# Altium schematic sheet 20-FPGA_2.SchDoc(20-FPGA_2)
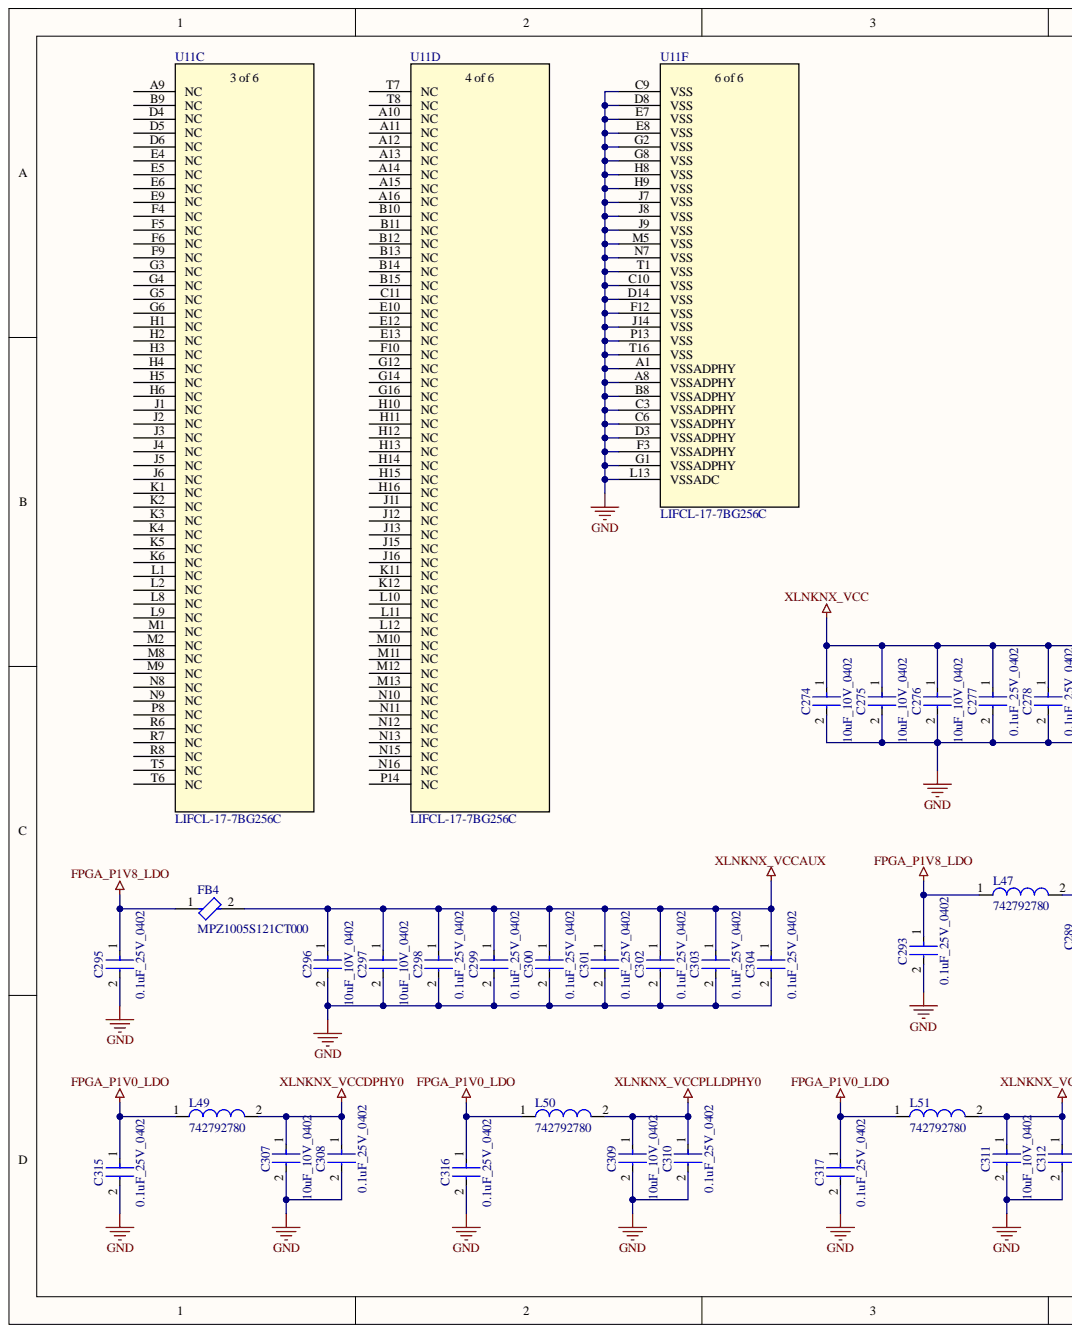
[diagram p0: 20-FPGA_2.SchDoc, left]
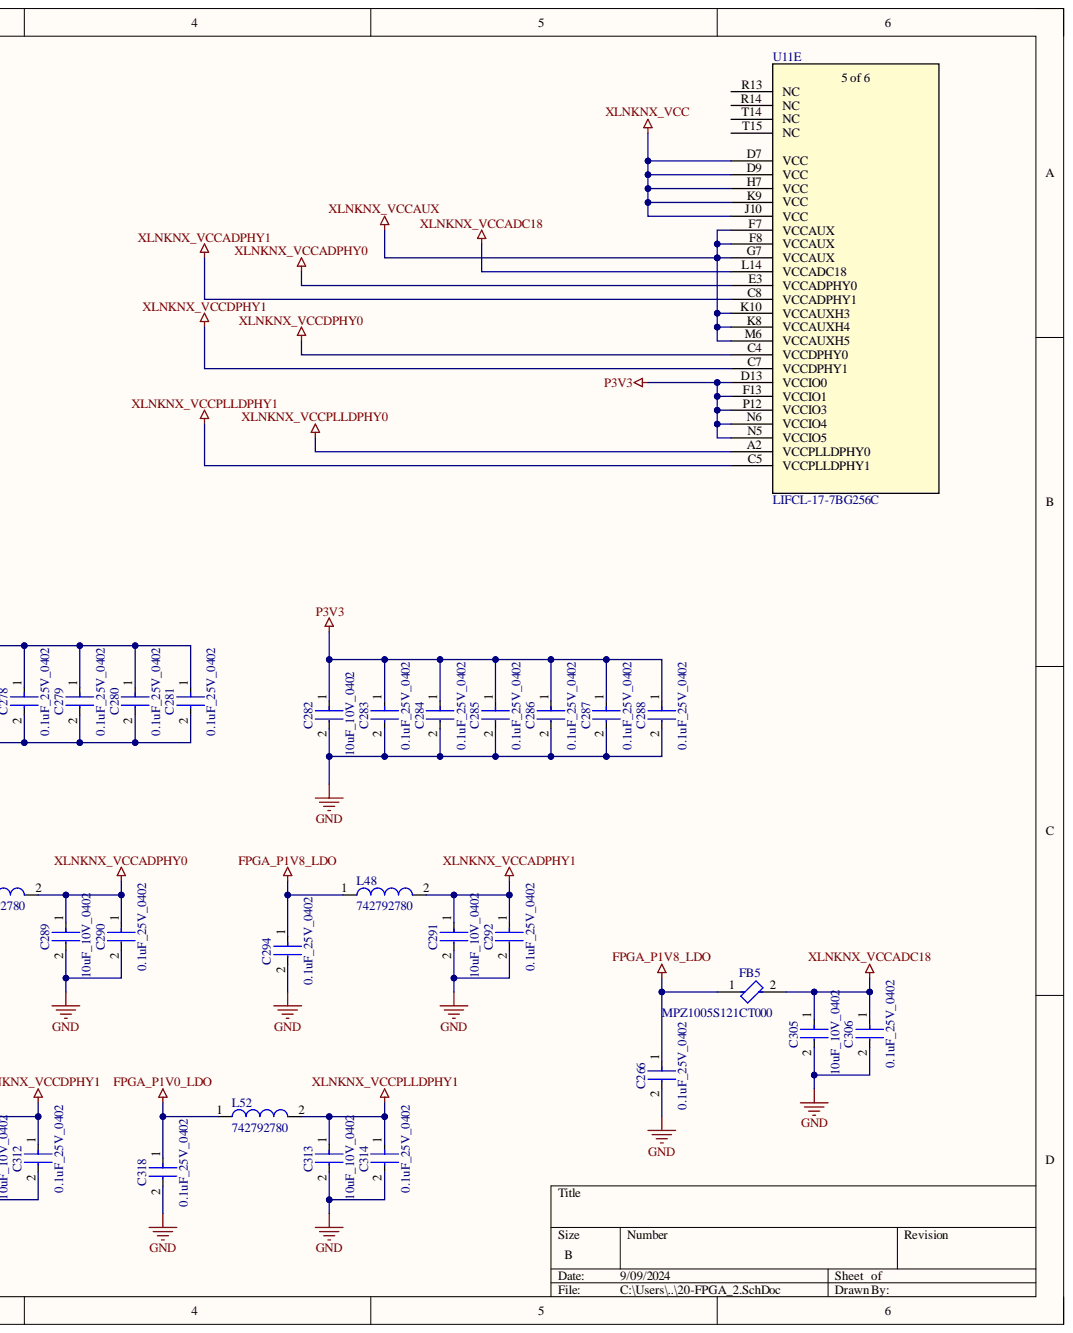
[diagram p1: 20-FPGA_2.SchDoc, right]

## Parts
C266  0.1uF_25V_0402  [FP-0402-L_1_0_1-W_0_5_0_1-IPC_C]
C274  10uF_10V_0402  [FP-CL05A106MP5NUNC-MFG]
C275  10uF_10V_0402  [FP-CL05A106MP5NUNC-MFG]
C276  10uF_10V_0402  [FP-CL05A106MP5NUNC-MFG]
C277  0.1uF_25V_0402  [FP-0402-L_1_0_1-W_0_5_0_1-IPC_C]
C278  0.1uF_25V_0402  [FP-0402-L_1_0_1-W_0_5_0_1-IPC_C]
C279  0.1uF_25V_0402  [FP-0402-L_1_0_1-W_0_5_0_1-IPC_C]
C280  0.1uF_25V_0402  [FP-0402-L_1_0_1-W_0_5_0_1-IPC_C]
C281  0.1uF_25V_0402  [FP-0402-L_1_0_1-W_0_5_0_1-IPC_C]
C282  10uF_10V_0402  [FP-CL05A106MP5NUNC-MFG]
C283  0.1uF_25V_0402  [FP-0402-L_1_0_1-W_0_5_0_1-IPC_C]
C284  0.1uF_25V_0402  [FP-0402-L_1_0_1-W_0_5_0_1-IPC_C]
C285  0.1uF_25V_0402  [FP-0402-L_1_0_1-W_0_5_0_1-IPC_C]
C286  0.1uF_25V_0402  [FP-0402-L_1_0_1-W_0_5_0_1-IPC_C]
C287  0.1uF_25V_0402  [FP-0402-L_1_0_1-W_0_5_0_1-IPC_C]
C288  0.1uF_25V_0402  [FP-0402-L_1_0_1-W_0_5_0_1-IPC_C]
C289  10uF_10V_0402  [FP-CL05A106MP5NUNC-MFG]
C290  0.1uF_25V_0402  [FP-0402-L_1_0_1-W_0_5_0_1-IPC_C]
C291  10uF_10V_0402  [FP-CL05A106MP5NUNC-MFG]
C292  0.1uF_25V_0402  [FP-0402-L_1_0_1-W_0_5_0_1-IPC_C]
C293  0.1uF_25V_0402  [FP-0402-L_1_0_1-W_0_5_0_1-IPC_C]
C294  0.1uF_25V_0402  [FP-0402-L_1_0_1-W_0_5_0_1-IPC_C]
C295  0.1uF_25V_0402  [FP-0402-L_1_0_1-W_0_5_0_1-IPC_C]
C296  10uF_10V_0402  [FP-CL05A106MP5NUNC-MFG]
C297  10uF_10V_0402  [FP-CL05A106MP5NUNC-MFG]
C298  0.1uF_25V_0402  [FP-0402-L_1_0_1-W_0_5_0_1-IPC_C]
C299  0.1uF_25V_0402  [FP-0402-L_1_0_1-W_0_5_0_1-IPC_C]
C300  0.1uF_25V_0402  [FP-0402-L_1_0_1-W_0_5_0_1-IPC_C]
C301  0.1uF_25V_0402  [FP-0402-L_1_0_1-W_0_5_0_1-IPC_C]
C302  0.1uF_25V_0402  [FP-0402-L_1_0_1-W_0_5_0_1-IPC_C]
C303  0.1uF_25V_0402  [FP-0402-L_1_0_1-W_0_5_0_1-IPC_C]
C304  0.1uF_25V_0402  [FP-0402-L_1_0_1-W_0_5_0_1-IPC_C]
C305  10uF_10V_0402  [FP-CL05A106MP5NUNC-MFG]
C306  0.1uF_25V_0402  [FP-0402-L_1_0_1-W_0_5_0_1-IPC_C]
C307  10uF_10V_0402  [FP-CL05A106MP5NUNC-MFG]
C308  0.1uF_25V_0402  [FP-0402-L_1_0_1-W_0_5_0_1-IPC_C]
C309  10uF_10V_0402  [FP-CL05A106MP5NUNC-MFG]
C310  0.1uF_25V_0402  [FP-0402-L_1_0_1-W_0_5_0_1-IPC_C]
C311  10uF_10V_0402  [FP-CL05A106MP5NUNC-MFG]
C312  0.1uF_25V_0402  [FP-0402-L_1_0_1-W_0_5_0_1-IPC_C]
C313  10uF_10V_0402  [FP-CL05A106MP5NUNC-MFG]
C314  0.1uF_25V_0402  [FP-0402-L_1_0_1-W_0_5_0_1-IPC_C]
C315  0.1uF_25V_0402  [FP-0402-L_1_0_1-W_0_5_0_1-IPC_C]
C316  0.1uF_25V_0402  [FP-0402-L_1_0_1-W_0_5_0_1-IPC_C]
C317  0.1uF_25V_0402  [FP-0402-L_1_0_1-W_0_5_0_1-IPC_C]
C318  0.1uF_25V_0402  [FP-0402-L_1_0_1-W_0_5_0_1-IPC_C]
FB4  MPZ1005S121CT000  [FP-MPZ1005-IPC_A]
FB5  MPZ1005S121CT000  [FP-MPZ1005-IPC_A]
L47  742792780  [SMD-0402]
L48  742792780  [SMD-0402]
L49  742792780  [SMD-0402]
L50  742792780  [SMD-0402]
L51  742792780  [SMD-0402]
L52  742792780  [SMD-0402]
U11  LIFCL-17-7BG256C  [caBGA256_LAT]  (parts C,D,E,F)

## Nets
FPGA_P1V0_LDO: C315.1 C316.1 C317.1 C318.1 L49.1 L50.1 L51.1 L52.1
FPGA_P1V8_LDO: C266.1 C293.1 C294.1 C295.1 FB4.1 FB5.1 L47.1 L48.1
GND: C266.2 C274.2 C275.2 C276.2 C277.2 C278.2 C279.2 C280.2 C281.2 C282.2 C283.2 C284.2 C285.2 C286.2 C287.2 C288.2 C289.2 C290.2 C291.2 C292.2 C293.2 C294.2 C295.2 C296.2 C297.2 C298.2 C299.2 C300.2 C301.2 C302.2 C303.2 C304.2 C305.2 C306.2 C307.2 C308.2 C309.2 C310.2 C311.2 C312.2 C313.2 C314.2 C315.2 C316.2 C317.2 C318.2 U11.A1(VSSADPHY) U11.A8(VSSADPHY) U11.B8(VSSADPHY) U11.C3(VSSADPHY) U11.C6(VSSADPHY) U11.C9(VSS) U11.C10(VSS) U11.D3(VSSADPHY) U11.D8(VSS) U11.D14(VSS) U11.E7(VSS) U11.E8(VSS) U11.F3(VSSADPHY) U11.F12(VSS) U11.G1(VSSADPHY) U11.G2(VSS) U11.G8(VSS) U11.H8(VSS) U11.H9(VSS) U11.J7(VSS) U11.J8(VSS) U11.J9(VSS) U11.J14(VSS) U11.L13(VSSADC) U11.M5(VSS) U11.N7(VSS) U11.P13(VSS) U11.T1(VSS) U11.T16(VSS)
P3V3: C282.1 C283.1 C284.1 C285.1 C286.1 C287.1 C288.1 U11.D13(VCCIO0) U11.F13(VCCIO1) U11.N5(VCCIO5) U11.N6(VCCIO4) U11.P12(VCCIO3)
XLNKNX_VCC: C274.1 C275.1 C276.1 C277.1 C278.1 C279.1 C280.1 C281.1 U11.D7(VCC) U11.D9(VCC) U11.H7(VCC) U11.J10(VCC) U11.K9(VCC)
XLNKNX_VCCADC18: C305.1 C306.1 FB5.2 U11.L14(VCCADC18)
XLNKNX_VCCADPHY0: C289.1 C290.1 L47.2 U11.E3(VCCADPHY0)
XLNKNX_VCCADPHY1: C291.1 C292.1 L48.2 U11.C8(VCCADPHY1)
XLNKNX_VCCAUX: C296.1 C297.1 C298.1 C299.1 C300.1 C301.1 C302.1 C303.1 C304.1 FB4.2 U11.F7(VCCAUX) U11.F8(VCCAUX) U11.G7(VCCAUX) U11.K8(VCCAUXH4) U11.K10(VCCAUXH3) U11.M6(VCCAUXH5)
XLNKNX_VCCDPHY0: C307.1 C308.1 L49.2 U11.C4(VCCDPHY0)
XLNKNX_VCCDPHY1: C311.1 C312.1 L51.2 U11.C7(VCCDPHY1)
XLNKNX_VCCPLLDPHY0: C309.1 C310.1 L50.2 U11.A2(VCCPLLDPHY0)
XLNKNX_VCCPLLDPHY1: C313.1 C314.1 L52.2 U11.C5(VCCPLLDPHY1)

Not connected: U11 A9(NC) A10(NC) A11(NC) A12(NC) A13(NC) A14(NC) A15(NC) A16(NC) B9(NC) B10(NC) B11(NC) B12(NC) B13(NC) B14(NC) B15(NC) C11(NC) D4(NC) D5(NC) D6(NC) E4(NC) E5(NC) E6(NC) E9(NC) E10(NC) E12(NC) E13(NC) F4(NC) F5(NC) F6(NC) F9(NC) F10(NC) G3(NC) G4(NC) G5(NC) G6(NC) G12(NC) G14(NC) G16(NC) H1(NC) H2(NC) H3(NC) H4(NC) H5(NC) H6(NC) H10(NC) H11(NC) H12(NC) H13(NC) H14(NC) H15(NC) H16(NC) J1(NC) J2(NC) J3(NC) J4(NC) J5(NC) J6(NC) J11(NC) J12(NC) J13(NC) J15(NC) J16(NC) K1(NC) K2(NC) K3(NC) K4(NC) K5(NC) K6(NC) K11(NC) K12(NC) L1(NC) L2(NC) L8(NC) L9(NC) L10(NC) L11(NC) L12(NC) M1(NC) M2(NC) M8(NC) M9(NC) M10(NC) M11(NC) M12(NC) M13(NC) N8(NC) N9(NC) N10(NC) N11(NC) N12(NC) N13(NC) N15(NC) N16(NC) P8(NC) P14(NC) R6(NC) R7(NC) R8(NC) R13(NC) R14(NC) T5(NC) T6(NC) T7(NC) T8(NC) T14(NC) T15(NC)

## Library data of the parts
C266 C277-C281 C283-C288 C290 C292-C295 C298-C304 C306 C308 C310 C312 C314-C318 = CMP-2008-02519-2: General Purpose Ceramic Capacitor, 0402, 100nF, 10%, X7R, 15%, 25V; Capacitance: 100nF; Case Code (Imperial): 0402; Case Code (Metric): 1005; Case/Package: 0402; Component Kind: Standard; Construction: Flat; Depth: 0.5mm; Dielectric: X7R; Lead Free: Lead Free; Length: 1mm; Library Name: Altium Content Vault; Max Operating Temperature: 125°C; Min Operating Temperature: -55°C; Mount: Surface Mount; Number of Pins: 2; Package Quantity: 1; Packaging: Tape and Reel; Radiation Hardening: No; REACH SVHC: No SVHC; RoHS Compliant: Yes; Series: -; Termination: SMD/SMT; Thickness: 0.022inch; Tolerance: 10%; Voltage: 25V; Voltage Rating: 25V; Voltage Rating (DC): 25V; Width: 0.02inch
C274-C276 C282 C289 C291 C296 C297 C305 C307 C309 C311 C313 = CMP-13271-000002-1: CAP CER 10UF 10V X5R 0402; Manufacturer: Samsung; Manufacturer Part Number: CL05A106MP5NUNC; Material: Ceramic; Temperature Coefficient: 15%; Tolerance: 20%; Automotive: No; Capacitance: 10uF; Category: Cap; Component Kind: Standard; ComponentLink2Description: Datasheet; ComponentLink2URL: http://www.samsungsem.com/kr/support/product-search/mlcc/__icsFiles/afieldfile/2019/05/10/19-CL05A106MP5NUNC.pdf; Device Class L1: Passive Components; Device Class L2: Capacitors; Device Class L3: Ceramic Capacitors; Digikey Description: CAP CER 10UF 10V X5R 0402; Height: 0.7mm; Ipc Land Pattern Name: CAPC10050X50; Lead Free: Yes; Library Name: Altium Content Vault; Octopart: https://octopart.com/cl05a106mp5nunc-samsung-22457395; Package: CL05A106MP5NUNC; RoHS: TRUE; Temperature: -55°C to +85°C; Temperature Characteristic: X5R; Temperature Range High: +85°C; Temperature Range Low: -55°C; Value: 10uF; Voltage Rating: 10V
FB4 FB5 = CMP-08257-000439-1: Power line Ferrite Bead 120Ω @ 100MHz 1.2A DCR 0.09Ω SMD 0402; DC Resistance (DCR): 90mR; Impedance: 120R; Tolerance: 25%; Case Code (Imperial): 0402; Case Code (Metric): 1005; Case/Package: 0402; Component Kind: Standard; Core Material: Ferrite; Current Rating: 1.2A; Height: 0.022inch; Lead Free: Lead Free; Length: 0.039inch; Library Name: Altium Content Vault; Max DC Current: 1.2A; Mount: Surface Mount; Package Quantity: 1; Packaging: Cut Tape; REACH SVHC: No SVHC; RoHS Compliant: Yes; Series: MPZ; Width: 0.02inch
L47-L52 = CMP-0220-00137-1: SMD EMI Suppression Ferrite Bead WE-CBF, Z = 220 Ohm; Impedance: 220 Ohm; Component Kind: Standard; ComponentLink1Description: Manufacturer Link; ComponentLink1URL: http://www.we-online.com; ComponentLink2Description: Datasheet; ComponentLink2URL: http://www.we-online.de/katalog/media/pdf/742792780.pdf; DatasheetVersion: ver 4, Jul-2009; Library Name: Altium Content Vault; PackageDescription: Chip Inductor, 2-Leads, Body 1.0 x 0.5 mm; PackageReference: SMD-0402; PackageVersion: 2009
U11 = LIFCL-17-7BG256C: No Description Available; Mfr_Name: Lattice; Component Kind: Standard; Library Name: LIFCL-17-7BG256C.SchLib; RefDes: RefDes; Type: Type
